# Altium schematic sheet Giro_scope_2.0.SchDoc
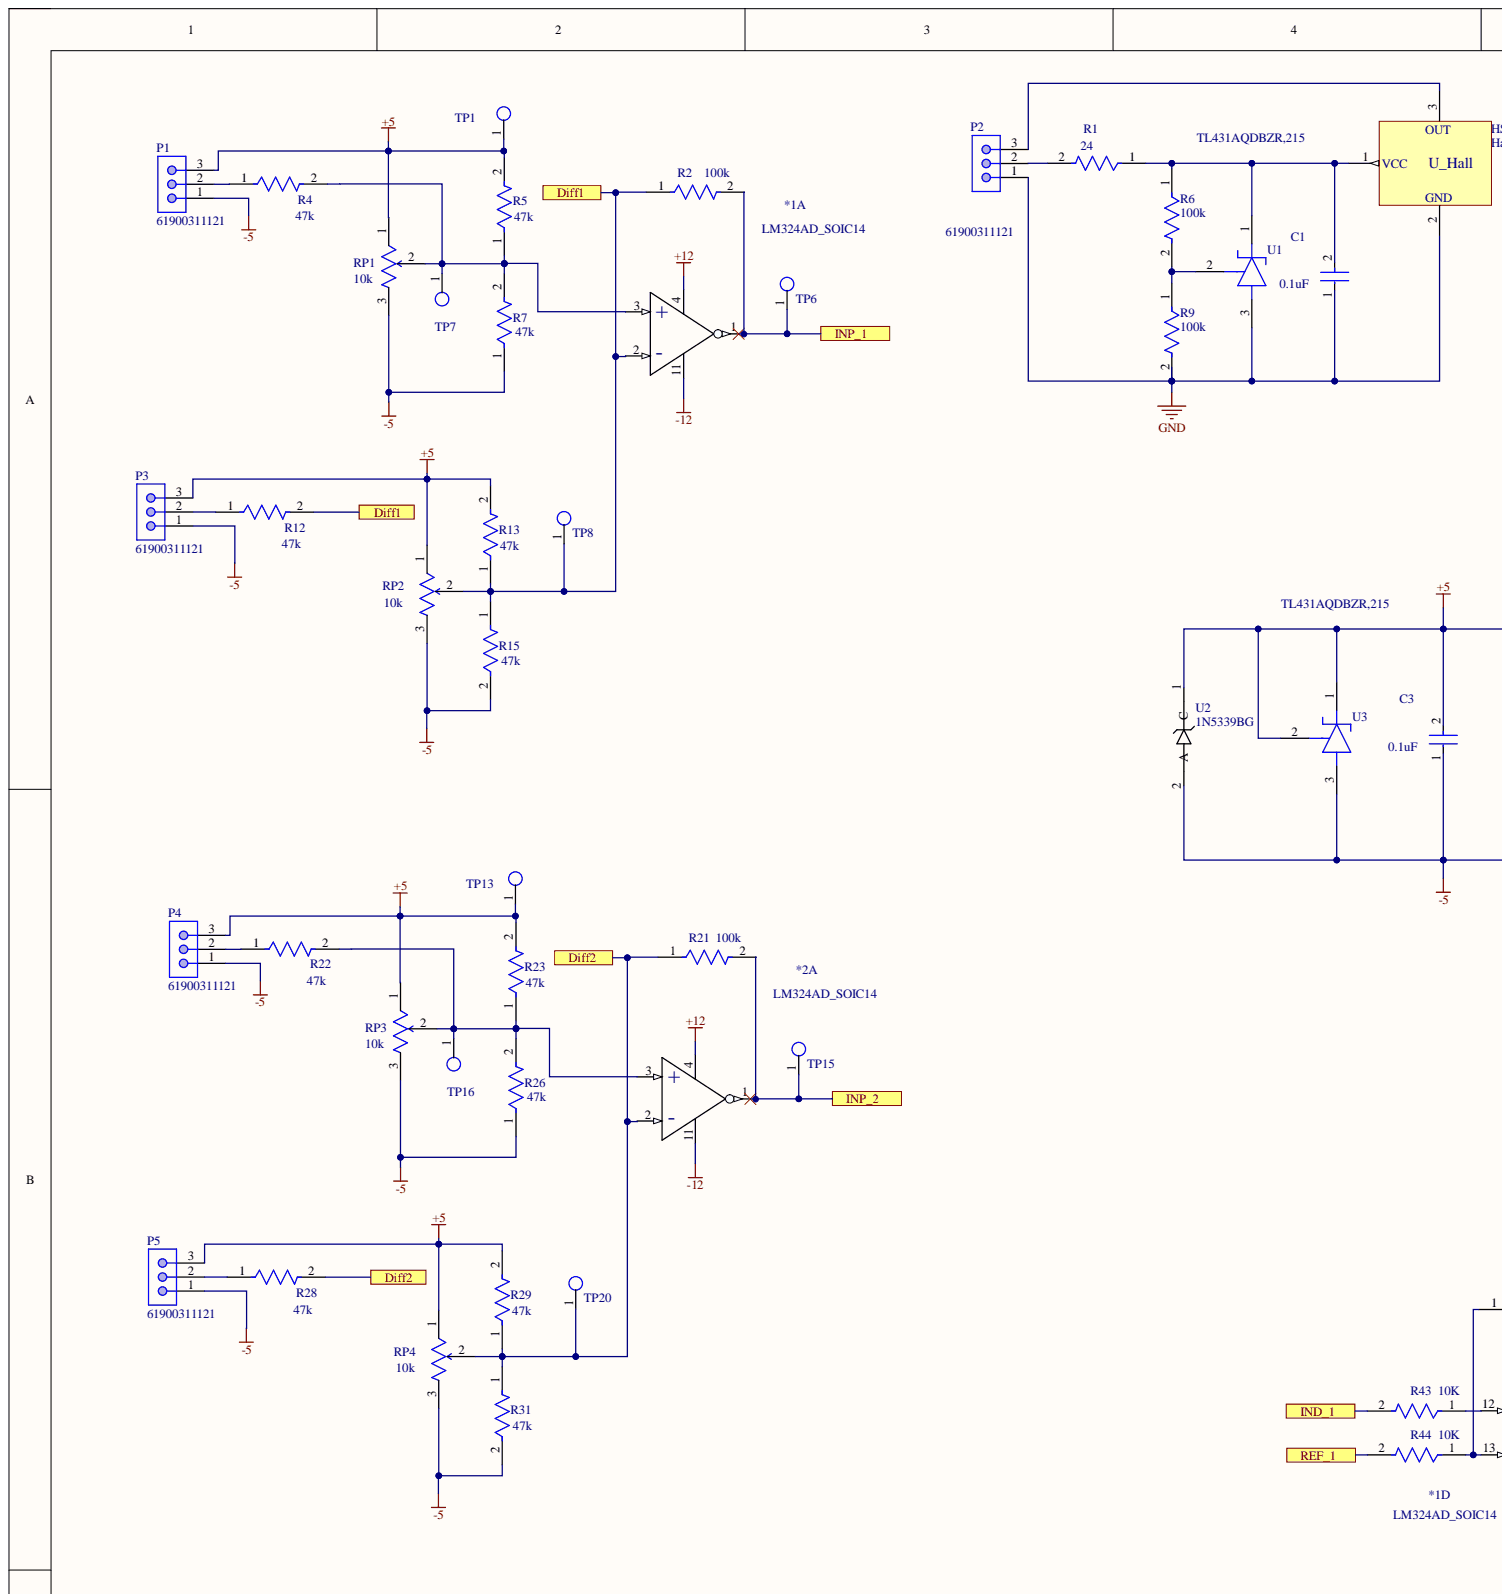
[diagram p0: Giro_scope_2.0.SchDoc, top left]
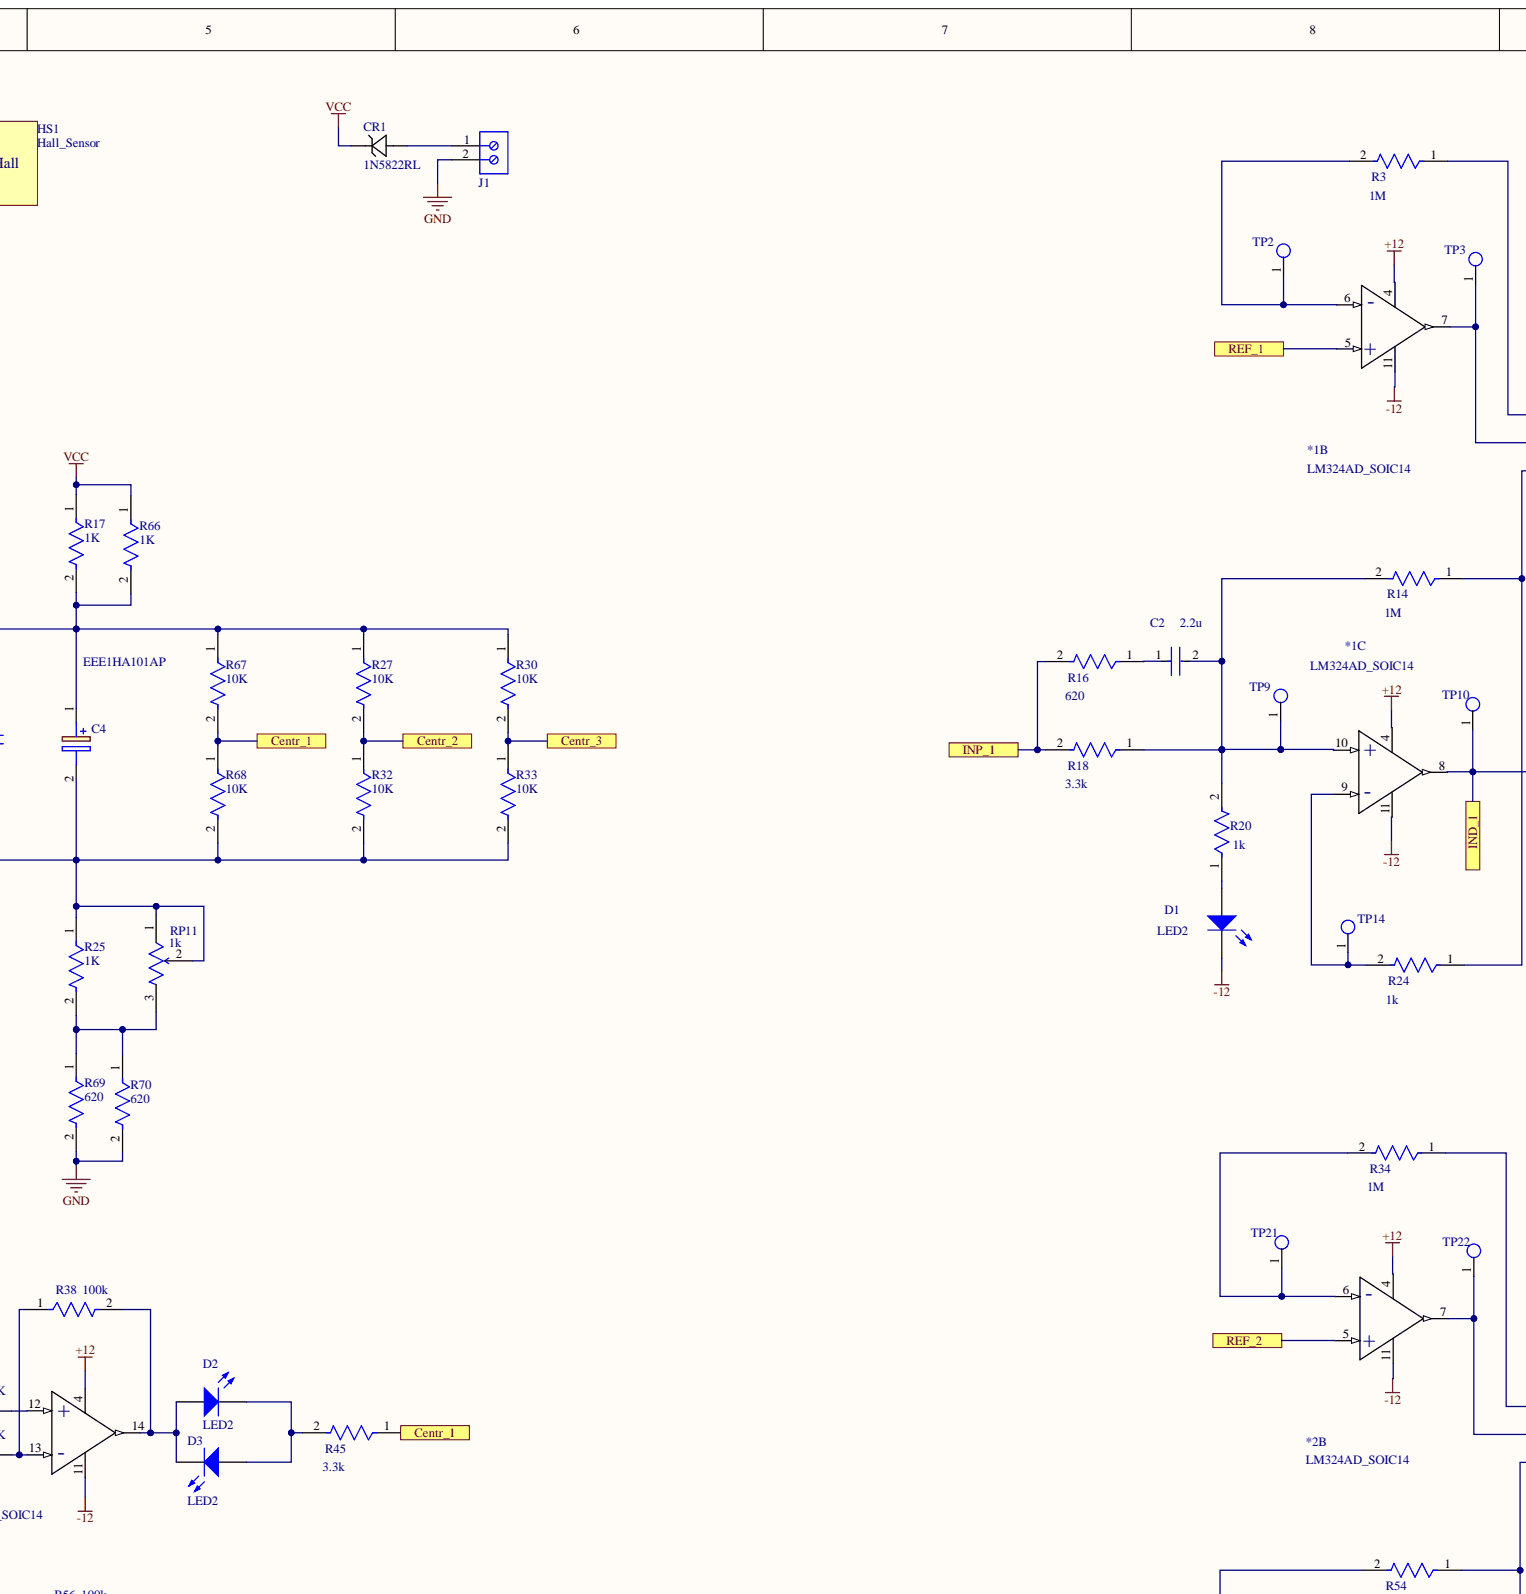
[diagram p1: Giro_scope_2.0.SchDoc, top centre]
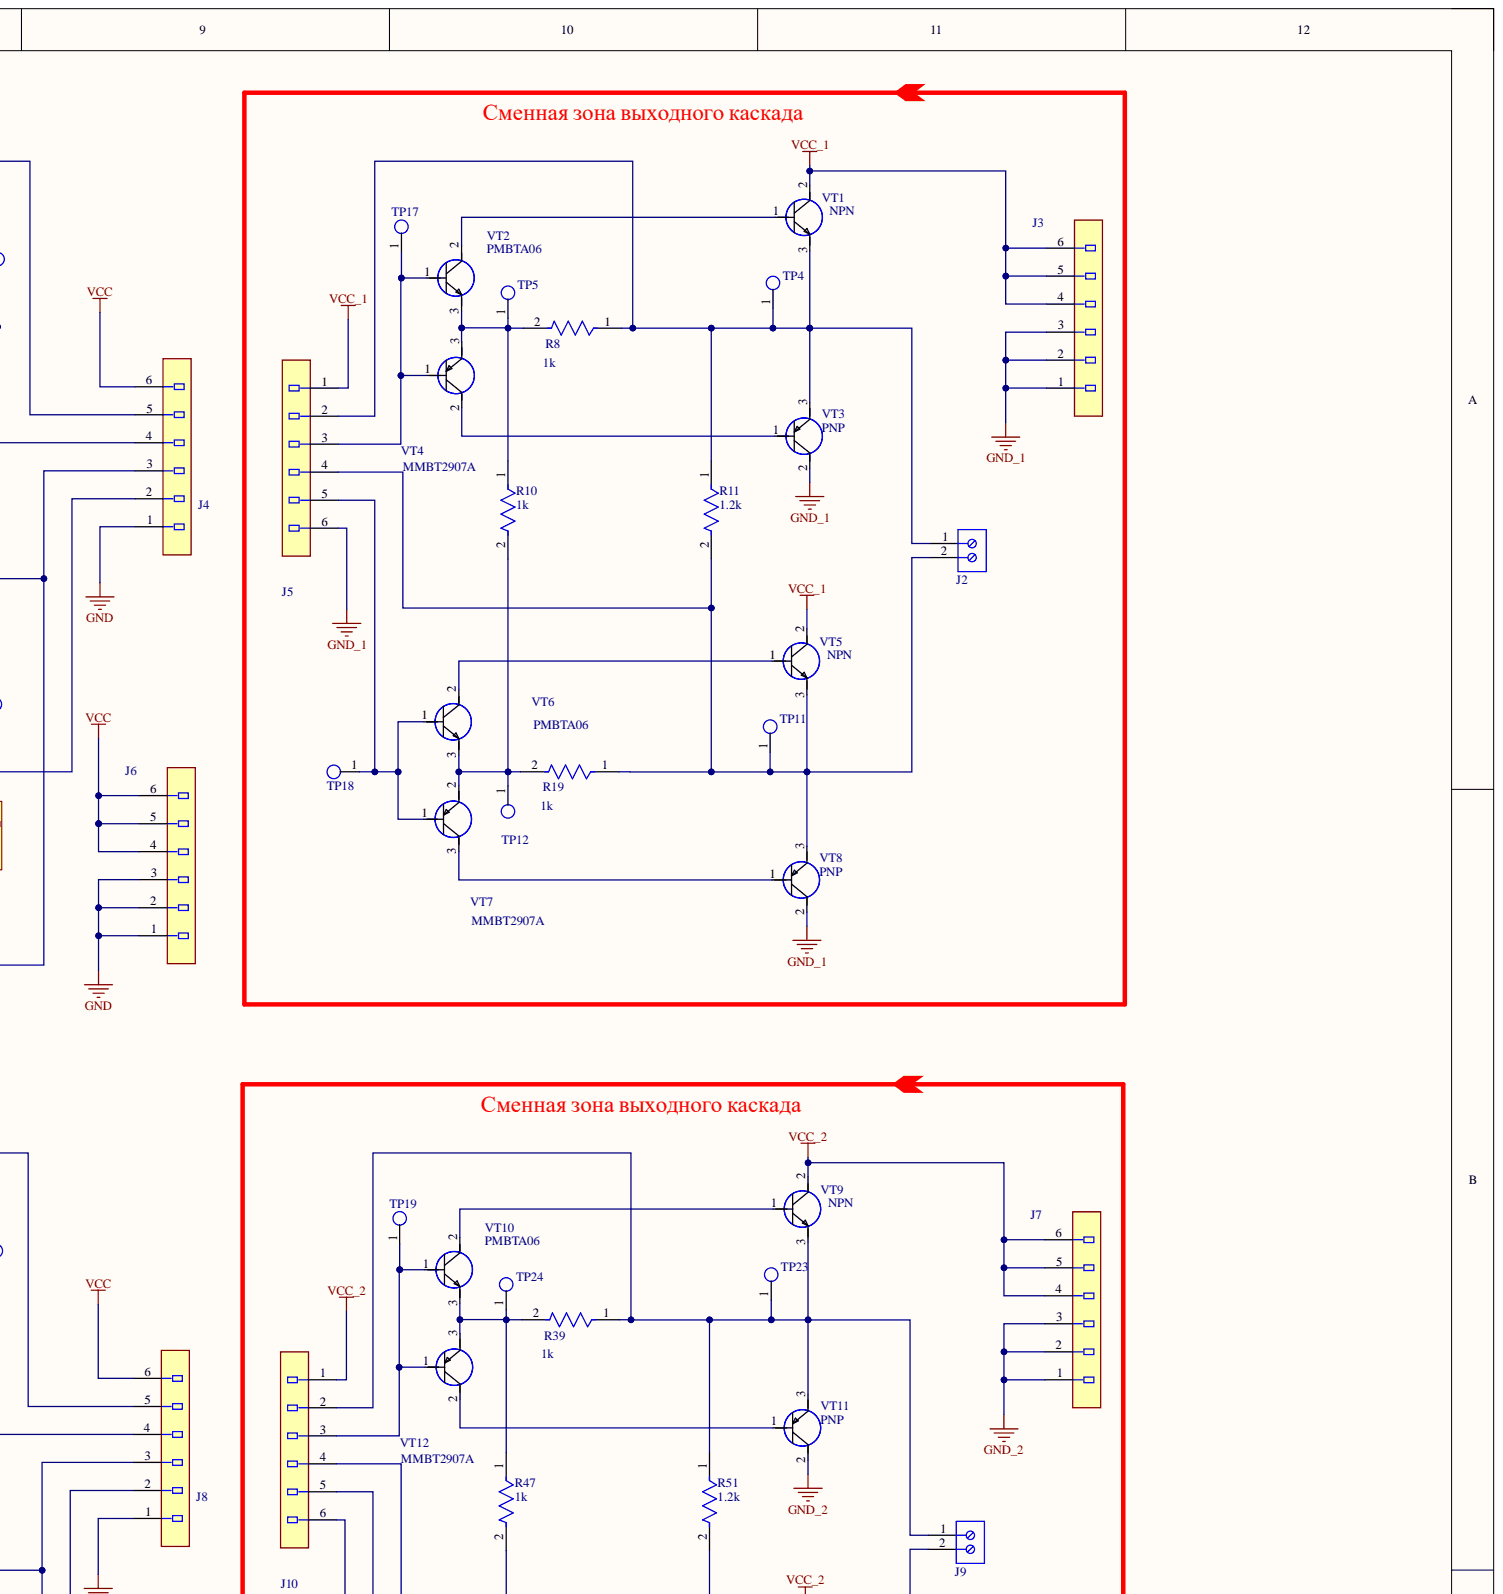
[diagram p2: Giro_scope_2.0.SchDoc, top right]
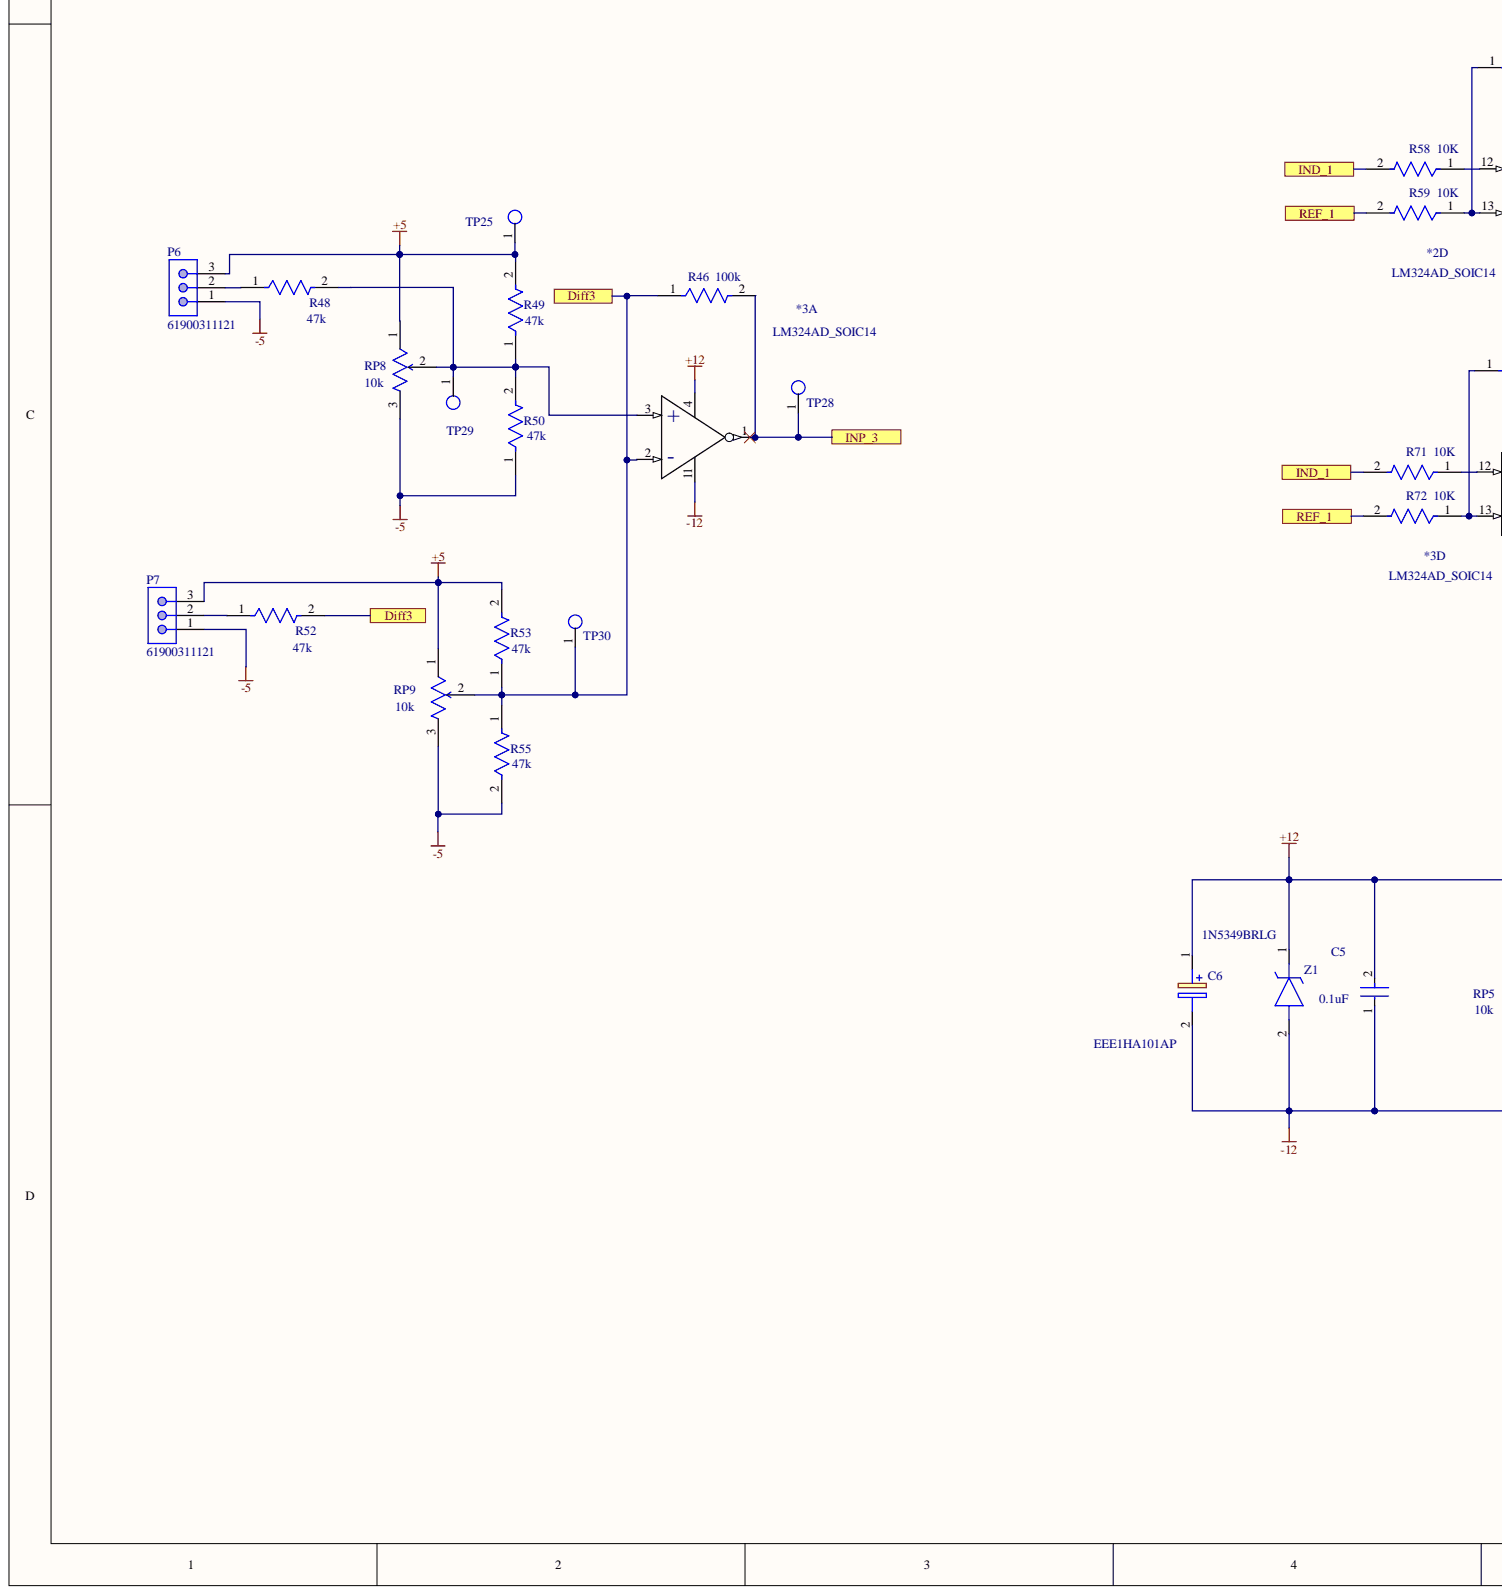
[diagram p3: Giro_scope_2.0.SchDoc, bottom left]
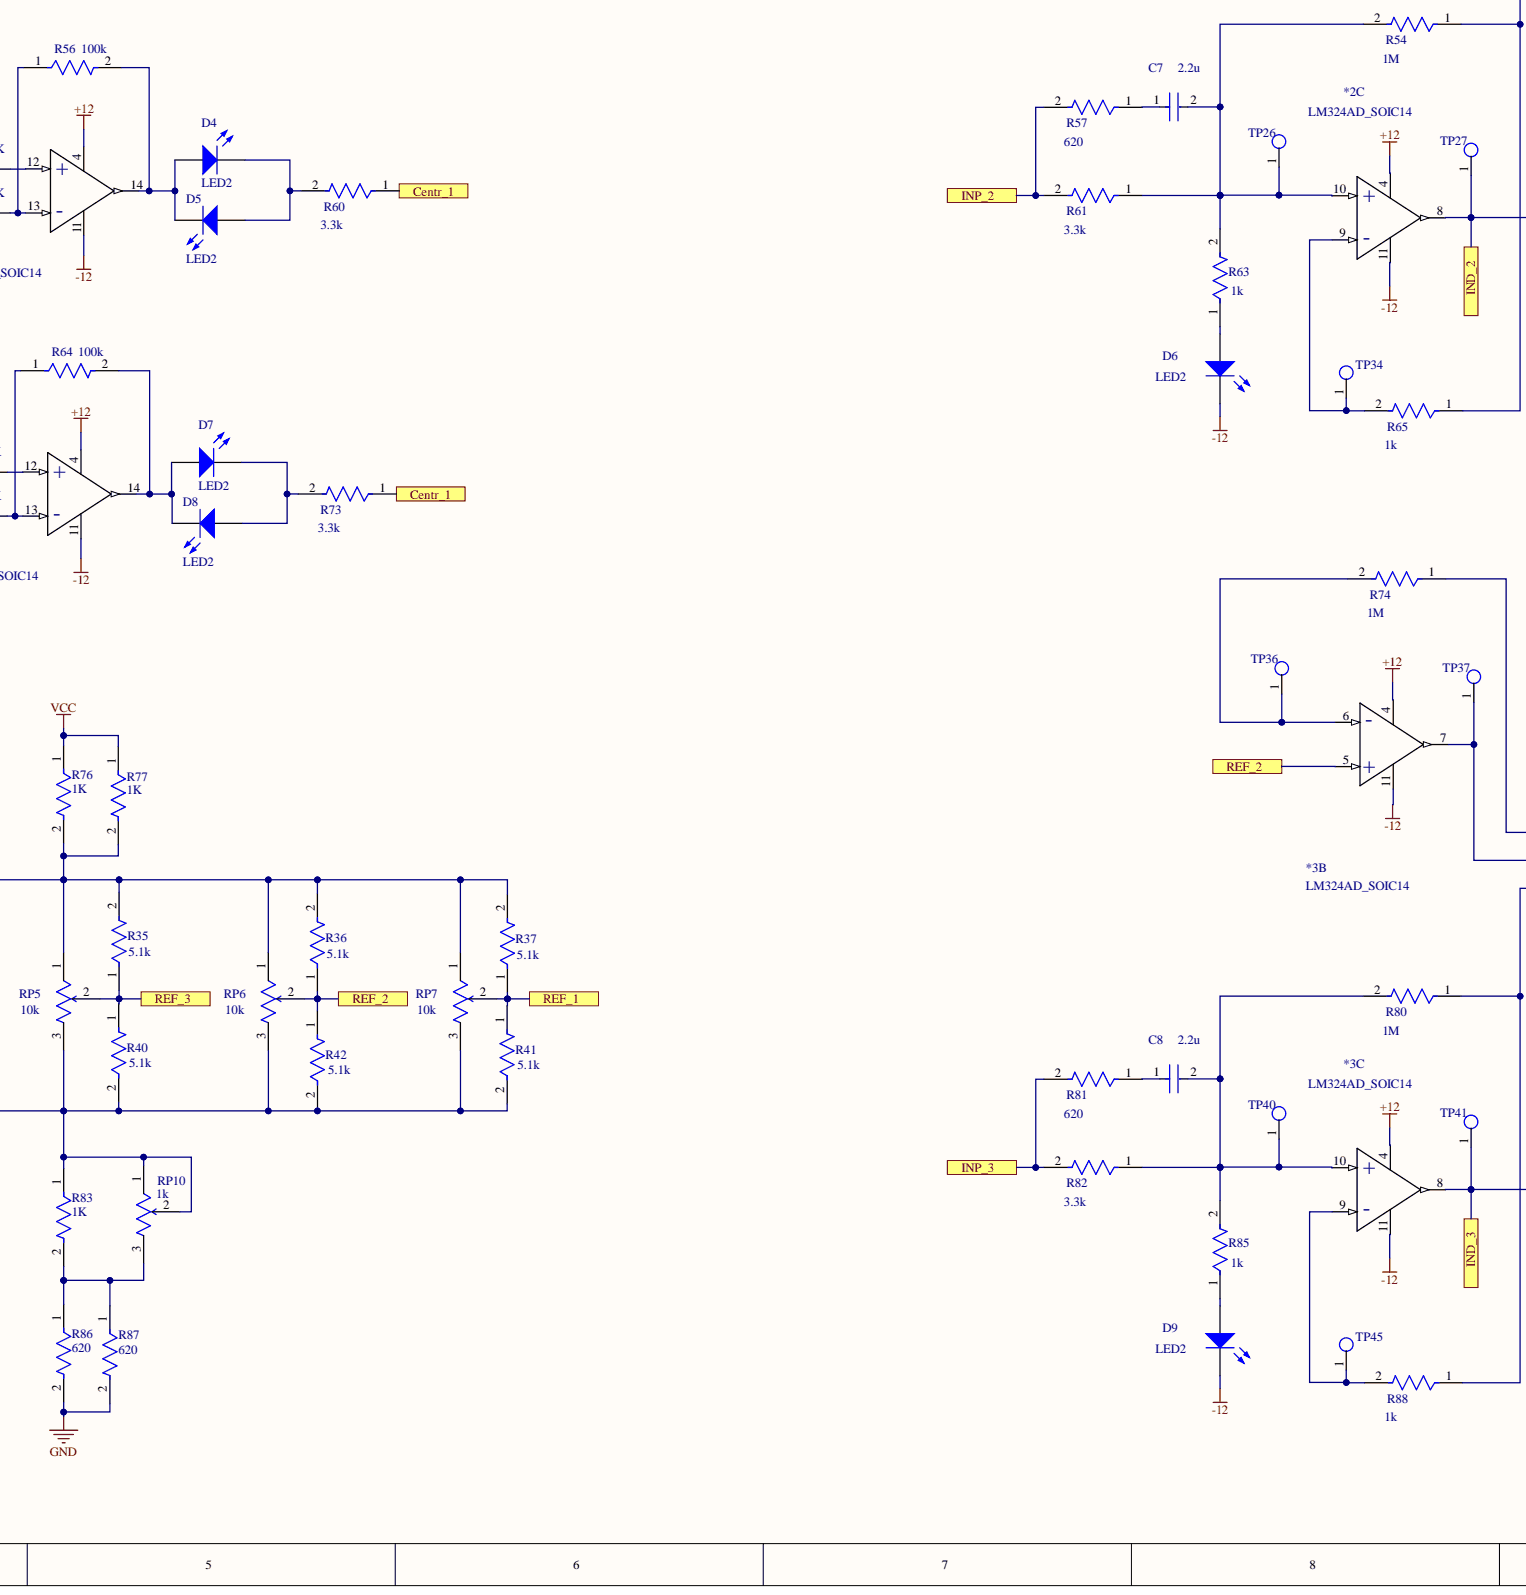
[diagram p4: Giro_scope_2.0.SchDoc, bottom centre]
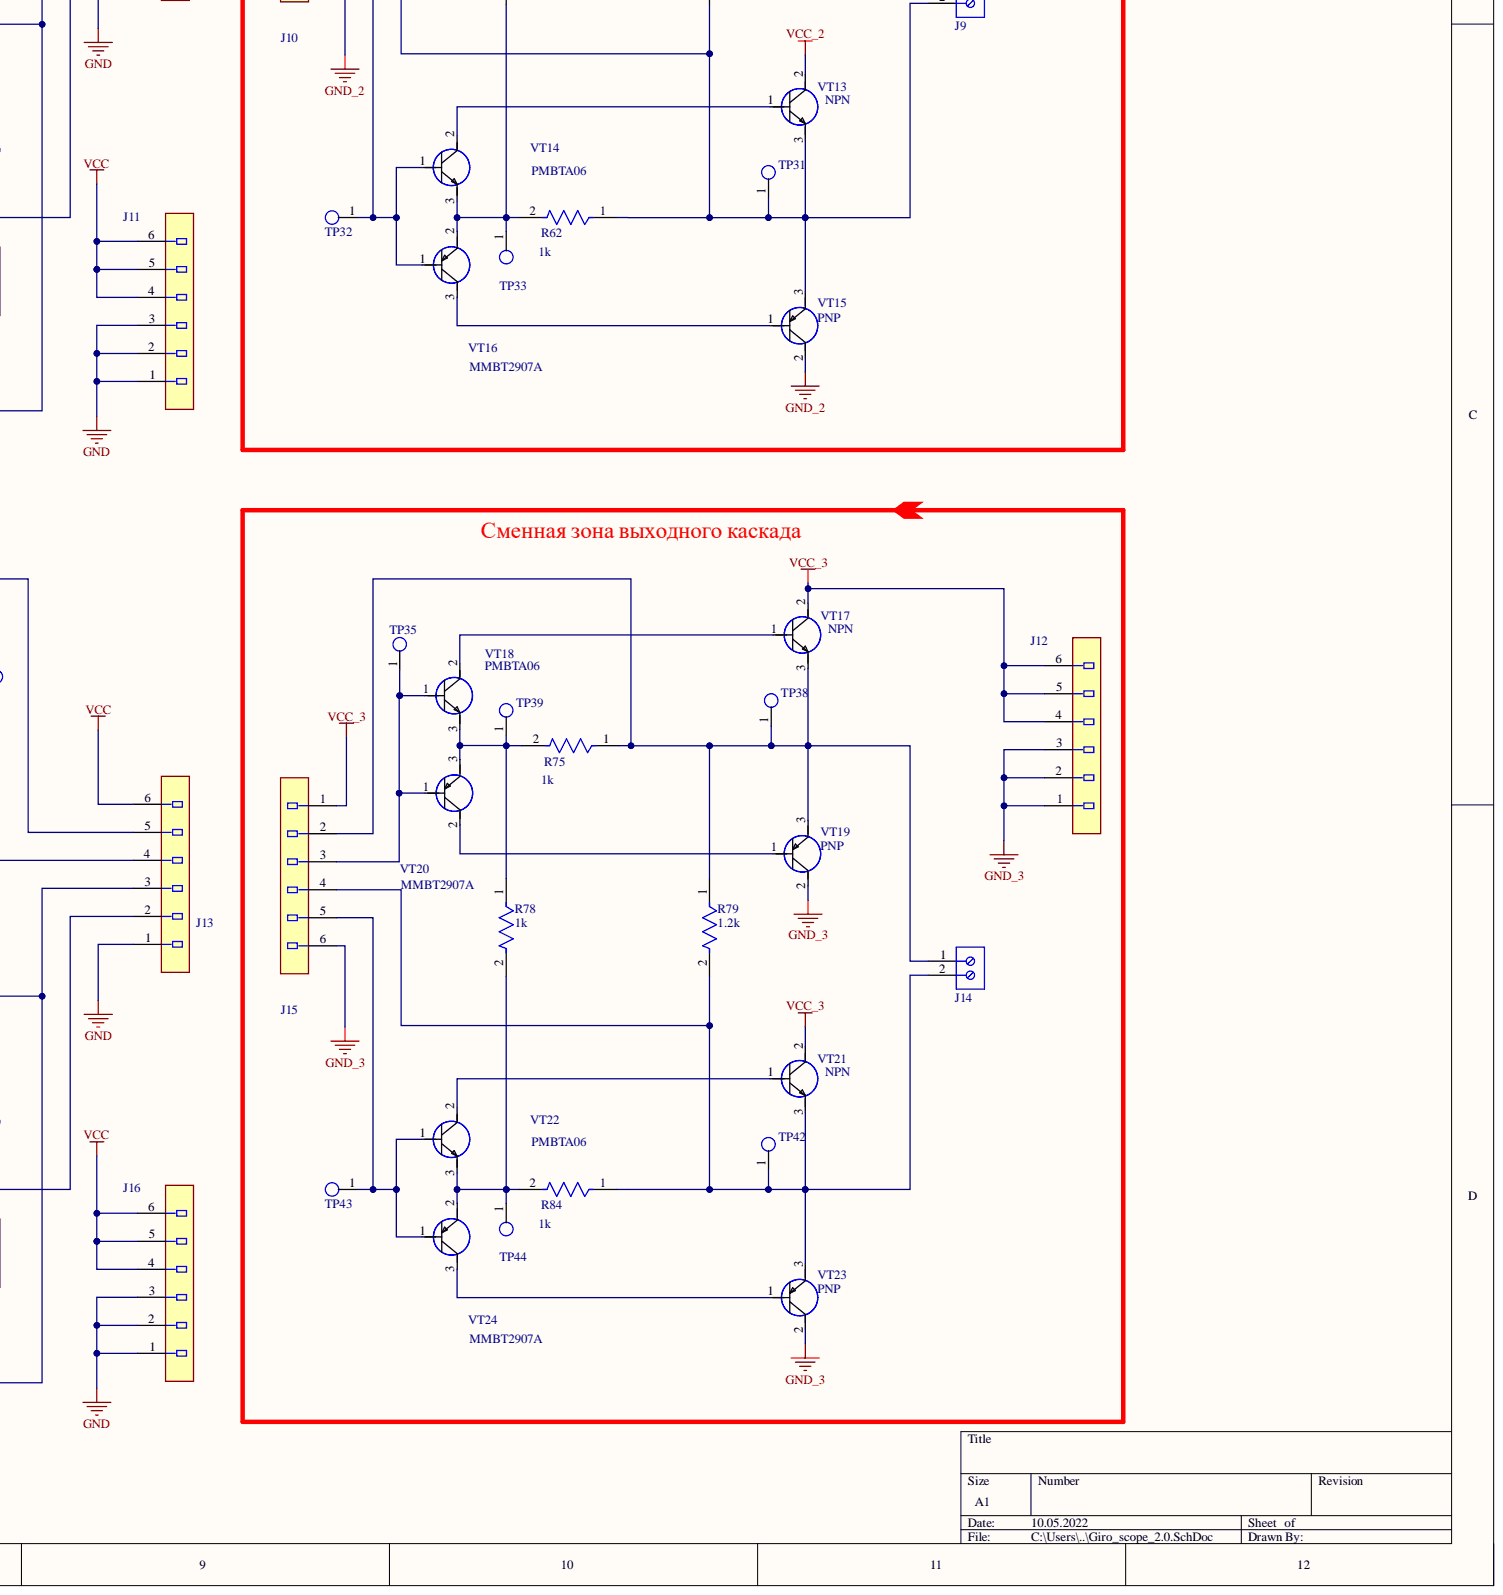
[diagram p5: Giro_scope_2.0.SchDoc, bottom right]

## Parts
*1  LM324AD_SOIC14  [D14-M]  (parts A,B,C,D)
*2  LM324AD_SOIC14  [D14-M]  (parts A,B,C,D)
*3  LM324AD_SOIC14  [D14-M]  (parts A,B,C,D)
C1  0.1uF  [KEMET_C0805C-DN_IPC_C]
C2  2.2u  [KEMET_C0805C-DN_IPC_A]
C3  0.1uF  [KEMET_C0805C-DN_IPC_C]
C4  EEE1HA101AP  [EEEFK1V331P]
C5  0.1uF  [KEMET_C0805C-DN_IPC_C]
C6  EEE1HA101AP  [EEEFK1V331P]
C7  2.2u  [KEMET_C0805C-DN_IPC_A]
C8  2.2u  [KEMET_C0805C-DN_IPC_A]
CR1  1N5822RL  [DO-201AD_STM]
D1  LED2  [Wurth_Electronics_0_MFG]
D2  LED2  [Wurth_Electronics_0_MFG]
D3  LED2  [Wurth_Electronics_0_MFG]
D4  LED2  [Wurth_Electronics_0_MFG]
D5  LED2  [Wurth_Electronics_0_MFG]
D6  LED2  [Wurth_Electronics_0_MFG]
D7  LED2  [Wurth_Electronics_0_MFG]
D8  LED2  [Wurth_Electronics_0_MFG]
D9  LED2  [Wurth_Electronics_0_MFG]
HS1  Hall_Sensor  [TO-92A]
J1  691103110003  [691101710002]  (value not drawn)
J2  691101710002  [691101710002]  (value not drawn)
J3  61300611121  [Wurth_61300611121_0]  (value not drawn)
J4  61300611121  [Wurth_61300611121_0]  (value not drawn)
J5  61300611121  [Wurth_61300611121_0]  (value not drawn)
J6  61300611121  [Wurth_61300611121_0]  (value not drawn)
J7  61300611121  [Wurth_61300611121_0]  (value not drawn)
J8  61300611121  [Wurth_61300611121_0]  (value not drawn)
J9  691101710002  [691101710002]  (value not drawn)
J10  61300611121  [Wurth_61300611121_0]  (value not drawn)
J11  61300611121  [Wurth_61300611121_0]  (value not drawn)
J12  61300611121  [Wurth_61300611121_0]  (value not drawn)
J13  61300611121  [Wurth_61300611121_0]  (value not drawn)
J14  691101710002  [691101710002]  (value not drawn)
J15  61300611121  [Wurth_61300611121_0]  (value not drawn)
J16  61300611121  [Wurth_61300611121_0]  (value not drawn)
P1  61900311121  [61900311121]
P2  61900311121  [61900311121]
P3  61900311121  [61900311121]
P4  61900311121  [61900311121]
P5  61900311121  [61900311121]
P6  61900311121  [61900311121]
P7  61900311121  [61900311121]
R1  24  [Vishay_CRCW0805-e3_IPC_B]
R2  100k  [Vishay_CRCW0805-e3_IPC_A]
R3  1M  [Vishay_CRCW0805-e3_IPC_A]
R4  47k  [Vishay_CRCW0805-e3_IPC_A]
R5  47k  [Vishay_CRCW0805-e3_IPC_A]
R6  100k  [Vishay_CRCW0805-e3_IPC_B]
R7  47k  [Vishay_CRCW0805-e3_IPC_A]
R8  1k  [Vishay_CRCW0805-e3_IPC_A]
R9  100k  [Vishay_CRCW0805-e3_IPC_B]
R10  1k  [Vishay_CRCW0805-e3_IPC_A]
R11  1.2k  [Vishay_CRCW0805-e3_IPC_A]
R12  47k  [Vishay_CRCW0805-e3_IPC_A]
R13  47k  [Vishay_CRCW0805-e3_IPC_A]
R14  1M  [Vishay_CRCW0805-e3_IPC_A]
R15  47k  [Vishay_CRCW0805-e3_IPC_A]
R16  620  [Vishay_CRCW0805-e3_IPC_A]
R17  1K  [Vishay_CRCW0805-e3_IPC_A]
R18  3.3k  [Vishay_CRCW0805-e3_IPC_A]
R19  1k  [Vishay_CRCW0805-e3_IPC_A]
R20  1k  [Vishay_CRCW0805-e3_IPC_A]
R21  100k  [Vishay_CRCW0805-e3_IPC_A]
R22  47k  [Vishay_CRCW0805-e3_IPC_A]
R23  47k  [Vishay_CRCW0805-e3_IPC_A]
R24  1k  [Vishay_CRCW0805-e3_IPC_A]
R25  1K  [Vishay_CRCW0805-e3_IPC_A]
R26  47k  [Vishay_CRCW0805-e3_IPC_A]
R27  10K  [Vishay_CRCW0805-e3_IPC_A]
R28  47k  [Vishay_CRCW0805-e3_IPC_A]
R29  47k  [Vishay_CRCW0805-e3_IPC_A]
R30  10K  [Vishay_CRCW0805-e3_IPC_A]
R31  47k  [Vishay_CRCW0805-e3_IPC_A]
R32  10K  [Vishay_CRCW0805-e3_IPC_A]
R33  10K  [Vishay_CRCW0805-e3_IPC_A]
R34  1M  [Vishay_CRCW0805-e3_IPC_A]
R35  5.1k  [Vishay_CRCW0805-e3_IPC_A]
R36  5.1k  [Vishay_CRCW0805-e3_IPC_A]
R37  5.1k  [Vishay_CRCW0805-e3_IPC_A]
R38  100k  [Vishay_CRCW0805-e3_IPC_A]
R39  1k  [Vishay_CRCW0805-e3_IPC_A]
R40  5.1k  [Vishay_CRCW0805-e3_IPC_A]
R41  5.1k  [Vishay_CRCW0805-e3_IPC_A]
R42  5.1k  [Vishay_CRCW0805-e3_IPC_A]
R43  10K  [Vishay_CRCW0805-e3_IPC_A]
R44  10K  [Vishay_CRCW0805-e3_IPC_A]
R45  3.3k  [Vishay_CRCW0805-e3_IPC_A]
R46  100k  [Vishay_CRCW0805-e3_IPC_A]
R47  1k  [Vishay_CRCW0805-e3_IPC_A]
R48  47k  [Vishay_CRCW0805-e3_IPC_A]
R49  47k  [Vishay_CRCW0805-e3_IPC_A]
R50  47k  [Vishay_CRCW0805-e3_IPC_A]
R51  1.2k  [Vishay_CRCW0805-e3_IPC_A]
R52  47k  [Vishay_CRCW0805-e3_IPC_A]
R53  47k  [Vishay_CRCW0805-e3_IPC_A]
R54  1M  [Vishay_CRCW0805-e3_IPC_A]
R55  47k  [Vishay_CRCW0805-e3_IPC_A]
R56  100k  [Vishay_CRCW0805-e3_IPC_A]
R57  620  [Vishay_CRCW0805-e3_IPC_A]
R58  10K  [Vishay_CRCW0805-e3_IPC_A]
R59  10K  [Vishay_CRCW0805-e3_IPC_A]
R60  3.3k  [Vishay_CRCW0805-e3_IPC_A]
R61  3.3k  [Vishay_CRCW0805-e3_IPC_A]
R62  1k  [Vishay_CRCW0805-e3_IPC_A]
R63  1k  [Vishay_CRCW0805-e3_IPC_A]
R64  100k  [Vishay_CRCW0805-e3_IPC_A]
R65  1k  [Vishay_CRCW0805-e3_IPC_A]
R66  1K  [Vishay_CRCW0805-e3_IPC_A]
R67  10K  [Vishay_CRCW0805-e3_IPC_A]
R68  10K  [Vishay_CRCW0805-e3_IPC_A]
R69  620  [Vishay_CRCW0805-e3_IPC_A]
R70  620  [Vishay_CRCW0805-e3_IPC_A]
R71  10K  [Vishay_CRCW0805-e3_IPC_A]
R72  10K  [Vishay_CRCW0805-e3_IPC_A]
R73  3.3k  [Vishay_CRCW0805-e3_IPC_A]
R74  1M  [Vishay_CRCW0805-e3_IPC_A]
R75  1k  [Vishay_CRCW0805-e3_IPC_A]
R76  1K  [Vishay_CRCW0805-e3_IPC_A]
R77  1K  [Vishay_CRCW0805-e3_IPC_A]
R78  1k  [Vishay_CRCW0805-e3_IPC_A]
R79  1.2k  [Vishay_CRCW0805-e3_IPC_A]
R80  1M  [Vishay_CRCW0805-e3_IPC_A]
R81  620  [Vishay_CRCW0805-e3_IPC_A]
R82  3.3k  [Vishay_CRCW0805-e3_IPC_A]
R83  1K  [Vishay_CRCW0805-e3_IPC_A]
R84  1k  [Vishay_CRCW0805-e3_IPC_A]
R85  1k  [Vishay_CRCW0805-e3_IPC_A]
R86  620  [Vishay_CRCW0805-e3_IPC_A]
R87  620  [Vishay_CRCW0805-e3_IPC_A]
R88  1k  [Vishay_CRCW0805-e3_IPC_A]
RP1  10k  [Bourns_3296W_MFG]
RP2  10k  [Bourns_3296W_MFG]
RP3  10k  [Bourns_3296W_MFG]
RP4  10k  [Bourns_3296W_MFG]
RP5  10k  [Bourns_3296W_MFG]
RP6  10k  [Bourns_3296W_MFG]
RP7  10k  [Bourns_3296W_MFG]
RP8  10k  [Bourns_3296W_MFG]
RP9  10k  [Bourns_3296W_MFG]
RP10  1k  [Bourns_3296W_MFG]
RP11  1k  [Bourns_3296W_MFG]
TP1  Test_Point_PCB  [Test_Point]  (value not drawn)
TP2  Test_Point_PCB  [Test_Point]  (value not drawn)
TP3  Test_Point_PCB  [Test_Point]  (value not drawn)
TP4  Test_Point_PCB  [Test_Point]  (value not drawn)
TP5  Test_Point_PCB  [Test_Point]  (value not drawn)
TP6  Test_Point_PCB  [Test_Point]  (value not drawn)
TP7  Test_Point_PCB  [Test_Point]  (value not drawn)
TP8  Test_Point_PCB  [Test_Point]  (value not drawn)
TP9  Test_Point_PCB  [Test_Point]  (value not drawn)
TP10  Test_Point_PCB  [Test_Point]  (value not drawn)
TP11  Test_Point_PCB  [Test_Point]  (value not drawn)
TP12  Test_Point_PCB  [Test_Point]  (value not drawn)
TP13  Test_Point_PCB  [Test_Point]  (value not drawn)
TP14  Test_Point_PCB  [Test_Point]  (value not drawn)
TP15  Test_Point_PCB  [Test_Point]  (value not drawn)
TP16  Test_Point_PCB  [Test_Point]  (value not drawn)
TP17  Test_Point_PCB  [Test_Point]  (value not drawn)
TP18  Test_Point_PCB  [Test_Point]  (value not drawn)
TP19  Test_Point_PCB  [Test_Point]  (value not drawn)
TP20  Test_Point_PCB  [Test_Point]  (value not drawn)
TP21  Test_Point_PCB  [Test_Point]  (value not drawn)
TP22  Test_Point_PCB  [Test_Point]  (value not drawn)
TP23  Test_Point_PCB  [Test_Point]  (value not drawn)
TP24  Test_Point_PCB  [Test_Point]  (value not drawn)
TP25  Test_Point_PCB  [Test_Point]  (value not drawn)
TP26  Test_Point_PCB  [Test_Point]  (value not drawn)
TP27  Test_Point_PCB  [Test_Point]  (value not drawn)
TP28  Test_Point_PCB  [Test_Point]  (value not drawn)
TP29  Test_Point_PCB  [Test_Point]  (value not drawn)
TP30  Test_Point_PCB  [Test_Point]  (value not drawn)
TP31  Test_Point_PCB  [Test_Point]  (value not drawn)
TP32  Test_Point_PCB  [Test_Point]  (value not drawn)
TP33  Test_Point_PCB  [Test_Point]  (value not drawn)
TP34  Test_Point_PCB  [Test_Point]  (value not drawn)
TP35  Test_Point_PCB  [Test_Point]  (value not drawn)
TP36  Test_Point_PCB  [Test_Point]  (value not drawn)
TP37  Test_Point_PCB  [Test_Point]  (value not drawn)
TP38  Test_Point_PCB  [Test_Point]  (value not drawn)
TP39  Test_Point_PCB  [Test_Point]  (value not drawn)
TP40  Test_Point_PCB  [Test_Point]  (value not drawn)
TP41  Test_Point_PCB  [Test_Point]  (value not drawn)
TP42  Test_Point_PCB  [Test_Point]  (value not drawn)
TP43  Test_Point_PCB  [Test_Point]  (value not drawn)
TP44  Test_Point_PCB  [Test_Point]  (value not drawn)
TP45  Test_Point_PCB  [Test_Point]  (value not drawn)
U1  IC_Nexperia_TL431AQDBZR,215_eec  [Nexperia_TL431AQDBZR,215_0]  (value not drawn)
U2  1N5339BG  [CASE_17AA-01_ONS]
U3  IC_Nexperia_TL431AQDBZR,215_eec  [Nexperia_TL431AQDBZR,215_0]  (value not drawn)
VT1  NPN  [TO-220AB]
VT2  PMBTA06  [SOT-23_ONS-L]
VT3  PNP
VT4  MMBT2907A  [SOT-23_ONS]
VT5  NPN  [TO-220AB]
VT6  PMBTA06  [SOT-23_ONS]
VT7  MMBT2907A  [SOT-23_ONS-M]
VT8  PNP
VT9  NPN  [TO-220AB]
VT10  PMBTA06  [SOT-23_ONS-L]
VT11  PNP
VT12  MMBT2907A  [SOT-23_ONS]
VT13  NPN  [TO-220AB]
VT14  PMBTA06  [SOT-23_ONS]
VT15  PNP
VT16  MMBT2907A  [SOT-23_ONS-M]
VT17  NPN  [TO-220AB]
VT18  PMBTA06  [SOT-23_ONS-L]
VT19  PNP
VT20  MMBT2907A  [SOT-23_ONS]
VT21  NPN  [TO-220AB]
VT22  PMBTA06  [SOT-23_ONS]
VT23  PNP
VT24  MMBT2907A  [SOT-23_ONS-M]
Z1  1N5349BRLG  [DIOAD2260W109L864D349]

## Ports
Centr_1, Centr_2, Centr_3, Diff1, Diff2, Diff3, IND_1, IND_2, IND_3, INP_1, INP_2, INP_3, REF_1, REF_2, REF_3

## Nets
+5: C3.2 C4.1 P1.3 P3.3 P4.3 P5.3 P6.3 P7.3 R5.2 R13.2 R17.2 R23.2 R27.1 R29.2 R30.1 R49.2 R53.2 R66.2 R67.1 RP1.1 RP2.1 RP3.1 RP4.1 RP8.1 RP9.1 TP1.1 TP13.1 TP25.1 U2.1 U3.1 U3.2
+12: *1.4 *2.4 *3.4 C5.2 C6.1 R35.2 R36.2 R37.2 R76.2 R77.2 RP5.1 RP6.1 RP7.1 Z1.1
-5: C3.1 C4.2 P1.1 P3.1 P4.1 P5.1 P6.1 P7.1 R7.1 R15.2 R25.1 R26.1 R31.2 R32.2 R33.2 R50.1 R55.2 R68.2 RP1.3 RP2.3 RP3.3 RP4.3 RP8.3 RP9.3 RP11.1 RP11.2 U2.2 U3.3
-12: *1.11 *2.11 *3.11 C5.1 C6.2 D1.2 D6.2 D9.2 R40.2 R41.2 R42.2 R83.1 RP5.3 RP6.3 RP7.3 RP10.1 RP10.2 Z1.2
GND: C1.1 HS1.2 J1.2 J4.1 J6.1 J6.2 J6.3 J8.1 J11.1 J11.2 J11.3 J13.1 J16.1 J16.2 J16.3 P2.1 R9.2 R69.2 R70.2 R86.2 R87.2 U1.3
GND_1: J3.1 J3.2 J3.3 J5.6 VT3.2 VT8.2
GND_2: J7.1 J7.2 J7.3 J10.6 VT11.2 VT15.2
GND_3: J12.1 J12.2 J12.3 J15.6 VT19.2 VT23.2
VCC: CR1.1 J4.6 J6.4 J6.5 J6.6 J8.6 J11.4 J11.5 J11.6 J13.6 J16.4 J16.5 J16.6 R17.1 R66.1 R76.1 R77.1
VCC_1: J3.4 J3.5 J3.6 J5.1 VT1.2 VT5.2
VCC_2: J7.4 J7.5 J7.6 J10.1 VT9.2 VT13.2
VCC_3: J12.4 J12.5 J12.6 J15.1 VT17.2 VT21.2
Centr_1: R45.1 R60.1 R67.2 R68.1 R73.1 ; port Centr_1
Centr_2: R27.2 R32.1 ; port Centr_2
Centr_3: R30.2 R33.1 ; port Centr_3
Diff1: *1.2(-) R2.1 R12.2 R13.1 R15.1 RP2.2 TP8.1 ; port Diff1
Diff2: *2.2(-) R21.1 R28.2 R29.1 R31.1 RP4.2 TP20.1 ; port Diff2
Diff3: *3.2(-) R46.1 R52.2 R53.1 R55.1 RP9.2 TP30.1 ; port Diff3
IND_1: *1.8 J4.2 R43.2 R58.2 R71.2 TP10.1 ; port IND_1
IND_2: *2.8 J8.2 TP27.1 ; port IND_2
IND_3: *3.8 J13.2 TP41.1 ; port IND_3
INP_1: *1.1 R2.2 R16.2 R18.2 TP6.1 ; port INP_1
INP_2: *2.1 R21.2 R57.2 R61.2 TP15.1 ; port INP_2
INP_3: *3.1 R46.2 R81.2 R82.2 TP28.1 ; port INP_3
REF_1: *1.5 R37.1 R41.1 R44.2 R59.2 R72.2 RP7.2 ; port REF_1
REF_2: *2.5 *3.5 R36.1 R42.1 RP6.2 ; port REF_2
REF_3: R35.1 R40.1 RP5.2 ; port REF_3
Net*1_3: *1.3(+) R4.2 R5.1 R7.2 RP1.2 TP7.1
Net*1_6: *1.6 R3.2 TP2.1
Net*1_7: *1.7 J4.4 TP3.1
Net*1_9: *1.9 R24.2 TP14.1
Net*1_10: *1.10 C2.2 R14.2 R18.1 R20.2 TP9.1
Net*1_12: *1.12 R43.1
Net*1_13: *1.13 R38.1 R44.1
Net*1_14: *1.14 D2.1 D3.2 R38.2
Net*2_3: *2.3(+) R22.2 R23.1 R26.2 RP3.2 TP16.1
Net*2_6: *2.6 R34.2 TP21.1
Net*2_7: *2.7 J8.4 TP22.1
Net*2_9: *2.9 R65.2 TP34.1
Net*2_10: *2.10 C7.2 R54.2 R61.1 R63.2 TP26.1
Net*2_12: *2.12 R58.1
Net*2_13: *2.13 R56.1 R59.1
Net*2_14: *2.14 D4.1 D5.2 R56.2
Net*3_3: *3.3(+) R48.2 R49.1 R50.2 RP8.2 TP29.1
Net*3_6: *3.6 R74.2 TP36.1
Net*3_7: *3.7 J13.4 TP37.1
Net*3_9: *3.9 R88.2 TP45.1
Net*3_10: *3.10 C8.2 R80.2 R82.1 R85.2 TP40.1
Net*3_12: *3.12 R71.1
Net*3_13: *3.13 R64.1 R72.1
Net*3_14: *3.14 D7.1 D8.2 R64.2
NetC1_2: C1.2 HS1.1 R1.1 R6.1 U1.1
NetC2_1: C2.1 R16.1
NetC7_1: C7.1 R57.1
NetC8_1: C8.1 R81.1
NetCR1_2: CR1.2 J1.1
NetD1_1: D1.1 R20.1
NetD2_2: D2.2 D3.1 R45.2
NetD4_2: D4.2 D5.1 R60.2
NetD6_1: D6.1 R63.1
NetD7_2: D7.2 D8.1 R73.2
NetD9_1: D9.1 R85.1
NetHS1_3: HS1.3 P2.3
NetJ2_1: J2.1 J5.2 R8.1 R11.1 TP4.1 VT1.3 VT3.3
NetJ2_2: J2.2 J5.4 R11.2 R19.1 TP11.1 VT5.3 VT8.3
NetJ4_3: J4.3 R14.1 R24.1
NetJ4_5: J4.5 R3.1
NetJ5_3: J5.3 TP17.1 VT2.1 VT4.1
NetJ5_5: J5.5 TP18.1 VT6.1 VT7.1
NetJ8_3: J8.3 R54.1 R65.1
NetJ8_5: J8.5 R34.1
NetJ9_1: J9.1 J10.2 R39.1 R51.1 TP23.1 VT9.3 VT11.3
NetJ9_2: J9.2 J10.4 R51.2 R62.1 TP31.1 VT13.3 VT15.3
NetJ10_3: J10.3 TP19.1 VT10.1 VT12.1
NetJ10_5: J10.5 TP32.1 VT14.1 VT16.1
NetJ13_3: J13.3 R80.1 R88.1
NetJ13_5: J13.5 R74.1
NetJ14_1: J14.1 J15.2 R75.1 R79.1 TP38.1 VT17.3 VT19.3
NetJ14_2: J14.2 J15.4 R79.2 R84.1 TP42.1 VT21.3 VT23.3
NetJ15_3: J15.3 TP35.1 VT18.1 VT20.1
NetJ15_5: J15.5 TP43.1 VT22.1 VT24.1
NetP1_2: P1.2 R4.1
NetP2_2: P2.2 R1.2
NetP3_2: P3.2 R12.1
NetP4_2: P4.2 R22.1
NetP5_2: P5.2 R28.1
NetP6_2: P6.2 R48.1
NetP7_2: P7.2 R52.1
NetR6_2: R6.2 R9.1 U1.2
NetR8_2: R8.2 R10.1 TP5.1 VT2.3 VT4.3
NetR10_2: R10.2 R19.2 TP12.1 VT6.3 VT7.2
NetR25_2: R25.2 R69.1 R70.1 RP11.3
NetR39_2: R39.2 R47.1 TP24.1 VT10.3 VT12.3
NetR47_2: R47.2 R62.2 TP33.1 VT14.3 VT16.2
NetR75_2: R75.2 R78.1 TP39.1 VT18.3 VT20.3
NetR78_2: R78.2 R84.2 TP44.1 VT22.3 VT24.2
NetR83_2: R83.2 R86.1 R87.1 RP10.3
NetVT1_1: VT1.1 VT2.2
NetVT3_1: VT3.1 VT4.2
NetVT5_1: VT5.1 VT6.2
NetVT7_3: VT7.3 VT8.1
NetVT9_1: VT9.1 VT10.2
NetVT11_1: VT11.1 VT12.2
NetVT13_1: VT13.1 VT14.2
NetVT15_1: VT15.1 VT16.3
NetVT17_1: VT17.1 VT18.2
NetVT19_1: VT19.1 VT20.2
NetVT21_1: VT21.1 VT22.2
NetVT23_1: VT23.1 VT24.3

## Library data of the parts
C1 C3 C5 = C0805C104J4RACTU: 100pF; Component Kind: Standard; Library Name: Cap_KEMET_C0805C104J4RACTU_eec.SchLib
C2 C7 C8 = C0805C104J4RACTU: Component Kind: Standard; Library Name: Cap_KEMET_C0805C104J4RACTU_eec.SchLib
C4 C6 = EEE1HA101AP: Capacitor Polarised; Manufacturer_Name: Panasonic; Component Kind: Standard; Datasheet Link: http://industrial.panasonic.com/cdbs/www-data/pdf/RDE0000/ABA0000C1142.pdf; Library Name: SamacSys.SchLib; Manufacturer_Part_Number: EEE1HA101AP
CR1 = 1N5822RL: No Description Available; Mfr_Name: STMicroelectronics; Type: DEV; Component Kind: Standard; Library Name: 1N5822RL.SchLib; Manufacturer_Part_Number: 1N5822RL; RefDes: RefDes; Copyright: Copyright (C) 2022 Ultra Librarian. All rights reserved.
D1-D9 = LED2: Typical RED, GREEN, YELLOW, AMBER GaAs LED; Component Kind: Standard; LatestRevisionDate: 17-Jul-2002; LatestRevisionNote: Re-released for DXP Platform.; Library Name: Miscellaneous Devices.IntLib; PackageDescription: SM LED; 2 Flat Leads; PackageReference: 3.2X1.6X1.1; PackageVersion: Feb-2002; Published: 8-Jun-2000; Simulation: LED2; Publisher: Altium Limited
J1 J2 J9 J14 = 691101710002: WR-TBL Series 101 Horizontal Entry Modular, Through Hole, pitch 5mm, 2p; Manufacturer: Wurth Elektronik; Modularity: Yes; PCB/Cable/Panel: PCB; Pin Number: 2; Rated Current: 16A; Working Voltage: 250V; Application: Pressure Clamp; Category: Terminal Blocks; Component Kind: Standard; ComponentLink1Description: Manufacturer Link; ComponentLink1URL: https://www.we-online.com/redexpert/article/691101710002?ad; ComponentLink2Description: Datasheet; ComponentLink2URL: https://www.we-online.com/redexpert/spec/691101710002?ad; Manufacturer Part Number: 691101710002; Match Code: WR-TBL; Mount: Through Hole; Operating Temperature Max: 105°C; Operating Temperature Min: -40°C; Orientation: Horizontal; Packaging: Box; Pitch: 5mm
  J1: Library Name: Altium_WR-TBL (rev22a) (1).IntLib
  J2 J9 J14: Library Name: WR-TBL.SchLib
P1-P7 = 61900311121: WR-WTB THT Male Vertical Locking Header, pitch 2.54mm, 3p; Contact Resistance: 20mOhm; Pin Number: 3; Rated Current: 3A; Withstanding Voltage: 1000VAC; Working Voltage: 250VAC; Component Kind: Standard; ComponentLink1Description: Manufacturer; ComponentLink1URL: http://www.we-online.de; ComponentLink2Description: Datasheet; ComponentLink2URL: https://www.we-online.com/catalog/datasheet/61900311121.pdf; Gender: Male; Library Name: Altium_WR-WTB (rev20a).IntLib; Mounting Technology: THT; Operating Temperature: -40 to +105degC; Packaging: Bag; Part Number: 61900311121; Pitch: 2.54mm; Published Date: January 2020; Type: Vertical; Publisher: Wurth Elektronik
R1-R88 = CRCW08050000Z0EA: Component Kind: Standard; Library Name: Res_Vishay_CRCW08050000Z0EA_eec.SchLib
  R1: Description: 24
  R2 R6 R9 R21 R38 R46 R56 R64: Description: 100k
  R3 R14 R34 R54 R74 R80: Description: 1M
  R4 R5 R7 R12 R13 R15 R22 R23 R26 R28 R29 R31 R48-R50 R52 R53 R55: Description: 47k
  R8 R10 R19 R20 R24 R39 R47 R62 R63 R65 R75 R78 R84 R85 R88: Description: 1k
  R11 R51 R79: Description: 1.2k
  R16 R57 R69 R70 R81 R86 R87: Description: 620
  R17 R25 R66 R76 R77 R83: Description: 1K
  R18 R45 R60 R61 R73 R82: Description: 3.3k
  R27 R30 R32 R33 R43 R44 R58 R59 R67 R68 R71 R72: Description: 10K
  R35-R37 R40-R42: Description: 5.1k
RP1-RP11 = 3296W-1-202LF: Component Kind: Standard; Library Name: Res_Bourns_3296W-1-202LF_eec.SchLib
  RP10 RP11: Description: 1k
TP1-TP45 = TP_PCB?: Test_Point; Component Kind: Standard; Library Name: Test_Point.SchLib
U1 U3 = IC_Nexperia_TL431AQDBZR,215_eec: IC VREF SHUNT ADJ SOT23-3; Current - Cathode: 600µA; Current - Output: 100mA; Number of Channels: 1; Other Names: 568-4890-6; Reference Type: Shunt, Adjustable, Precision; Tolerance: ±1%; Voltage - Input: 2.495 V ~ 36 V; Component Kind: Standard; ComponentLink1Description: Upverter; ComponentLink1URL: https://upverter.com/upn/revision/897efb4cb52176e6/; ComponentLink2Description: Datasheet; ComponentLink2URL: http://assets.nexperia.com/documents/data-sheet/TL431_FAM.pdf; Digikey Description: IC VREF SHUNT ADJ SOT23-3; Digikey Part Number: 1727-4259-1-ND; helpURL: https://upverter.com/upn/revision/897efb4cb52176e6/; Lead Free: yes; Library Name: IC_Nexperia_TL431AQDBZR,215_eec.SchLib; Manufacturer: Nexperia; Mounting Type: Surface Mount; Mouser Part Number: 771-TL431AQDBZR215; Operating Temperature: -40°C ~ 125°C; Package: TO-236-3, SC-59, SOT-23-3; Packaging: Digi-Reel®; Part Number: TL431AQDBZR,215; Price: 0.155797334872; RoHS: TRUE; Standard Package: 1; Supplier 1: Digi-Key; Supplier 2: Mouser; Supplier Part Number 1: 1727-4259-1-ND; Supplier Part Number 2: 771-TL431AQDBZR215; Temperature: -40°C to +125°C; Temperature Range High: +125°C; Temperature Range Low: -40°C; Voltage - Output: 2.495 V ~ 36 V
U2 = 1N5339BG: No Description Available; Mfr_Name: onsemi; Component Kind: Standard; Library Name: 1N5339BG.SchLib; Manufacturer_Part_Number: 1N5339BG; RefDes: RefDes; Type: DEV; Copyright: Copyright (C) 2022 Ultra Librarian. All rights reserved.
VT2 VT6 VT10 VT14 VT18 VT22 = NPN: PMBTA06 (S8050); Component Kind: Standard; Library Name: NPN.SchLib
VT4 VT7 VT12 VT16 VT20 VT24 = PNP: MMBT2907A (S8550G); Component Kind: Standard; Library Name: PNP.SchLib
Z1 = 1N5349BRLG: Zener Diode; Manufacturer_Name: onsemi; Arrow Part Number: 1N5349BRLG; Arrow Price/Stock: https://www.arrow.com/en/products/1n5349brlg/on-semiconductor?region=nac; Component Kind: Standard; Datasheet Link: https://datasheet.datasheetarchive.com/originals/distributors/SFDatasheet-3/sf-00075052.pdf; Library Name: SamacSys.SchLib; Manufacturer_Part_Number: 1N5349BRLG; Mouser Part Number: 863-1N5349BRLG; Mouser Price/Stock: https://www.mouser.co.uk/ProductDetail/onsemi/1N5349BRLG?qs=y2kkmE52mdPPPvJa3zV6XA%3D%3D
*1-*3 = LM324AD_SOIC14: Component Kind: Standard; Library Name: LM324AD_SOIC14.SchLib
HS1 = Hall_Sensor: Component Kind: Standard; Library Name: Schlib1.SchLib
J3-J8 J10-J13 J15 J16 = 61300611121: Component Kind: Standard; Library Name: Conn_Wurth_61300611121_eec.SchLib
VT1 VT5 VT9 VT13 VT17 VT21 = NPN: Component Kind: Standard; Library Name: NPN.SchLib
VT3 VT8 VT11 VT15 VT19 VT23 = PNP: Component Kind: Standard; Library Name: PNP.SchLib
